# Altium schematic sheet mcu.SchDoc
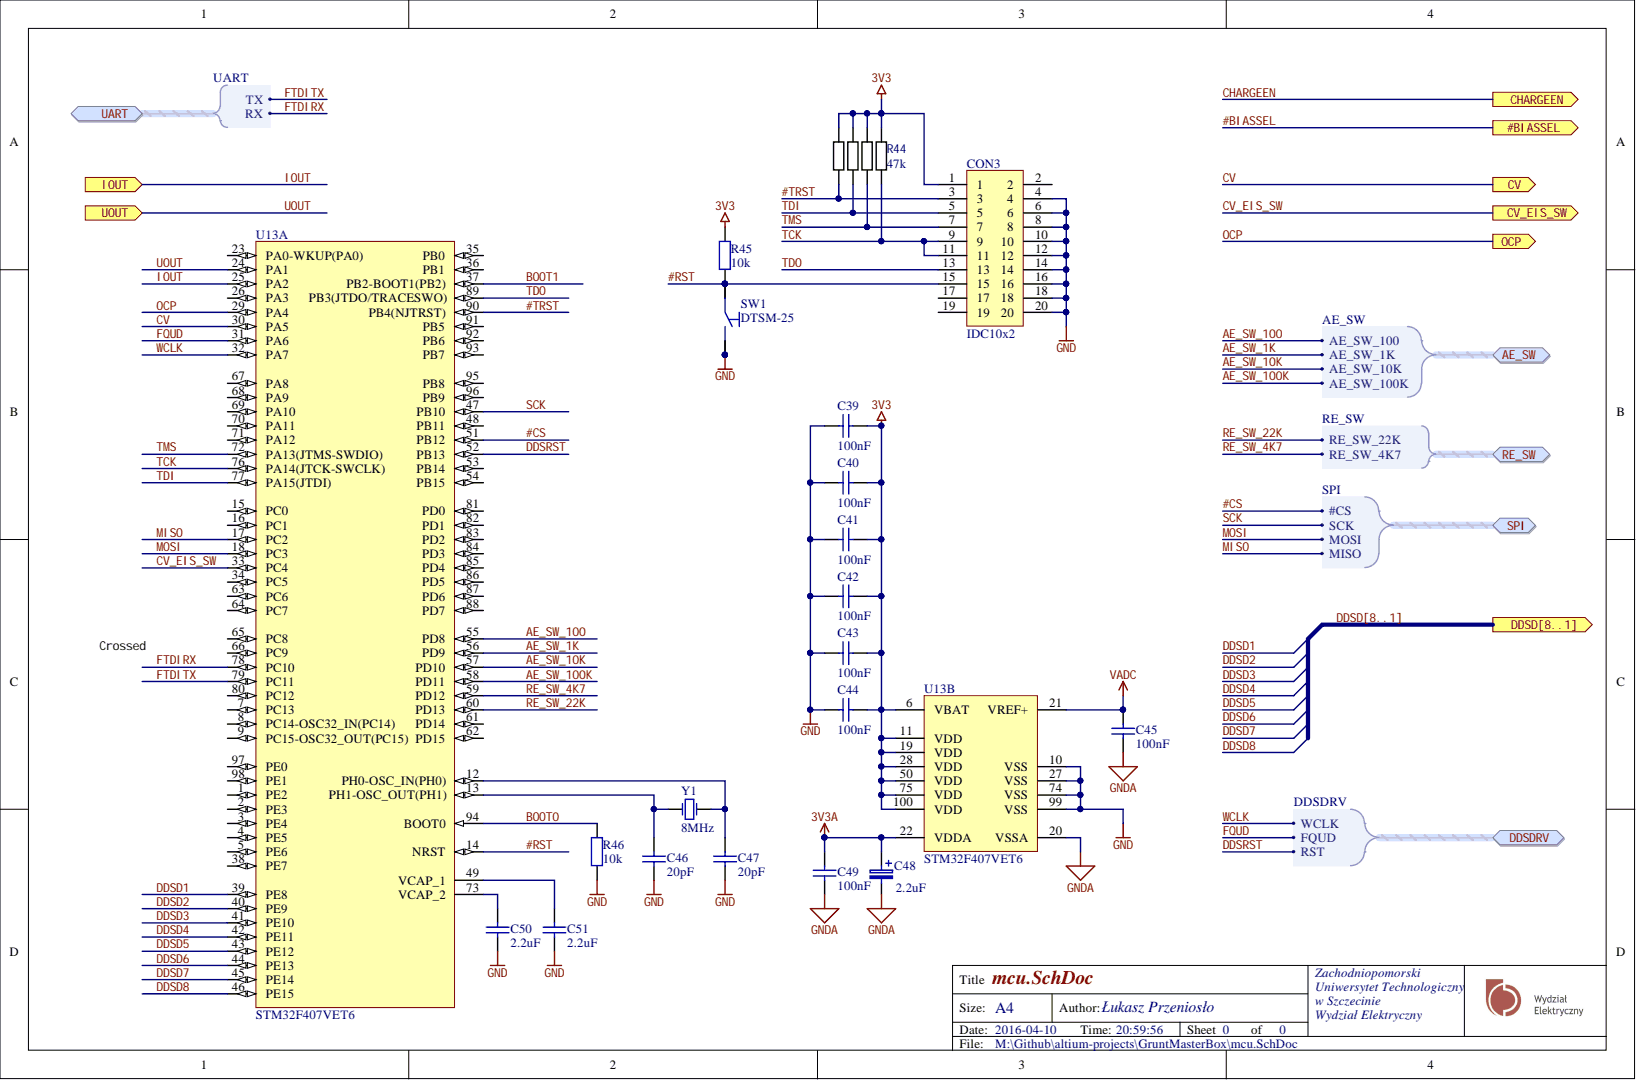
[diagram p0: mcu.SchDoc]

## Parts
C39  100nF  [CAPC1608N]
C40  100nF  [CAPC1608N]
C41  100nF  [CAPC1608N]
C42  100nF  [CAPC1608N]
C43  100nF  [CAPC1608N]
C44  100nF  [CAPC1608N]
C45  100nF  [CAPC1608N]
C46  20pF  [CAPC1608N]
C47  20pF  [CAPC1608N]
C48  2.2uF  [SMDA]
C49  100nF  [CAPC1608N]
C50  2.2uF  [CAPC1608N]
C51  2.2uF  [CAPC1608N]
CON3  IDC10x2  [IDC20_10x2]
R44  47k  [RES_ARRAY_4x0603]
R45  10k  [RESC1608N]
R46  10k  [RESC1608N]
SW1  DTSM-25  [DTSM-25]
U13  STM32F407VET6  [LQFP100_L]  (parts A,B)
Y1  8MHz  [HC49/4H_SMX]

## Ports
#BIASSEL, AE_SW, AE_SW.AE_SW_1K, AE_SW.AE_SW_10K, AE_SW.AE_SW_100, AE_SW.AE_SW_100K, CHARGEEN, CV, CV_EIS_SW, DDSD1, DDSD2, DDSD3, DDSD4, DDSD5, DDSD6, DDSD7, DDSD8, DDSD[8..1], DDSDRV, DDSDRV.FQUD, DDSDRV.RST, DDSDRV.WCLK, IOUT, OCP, RE_SW, RE_SW.RE_SW_4K7, RE_SW.RE_SW_22K, SPI, SPI.#CS, SPI.MISO, SPI.MOSI, SPI.SCK, UART, UART.RX, UART.TX, UOUT

## Nets
3V3: C39.2 C40.2 C41.2 C42.2 C43.2 C44.2 CON3.1 R44.2 R44.4 R44.6 R44.8 R45.2 U13.6(VBAT) U13.11(VDD) U13.19(VDD) U13.28(VDD) U13.50(VDD) U13.75(VDD) U13.100(VDD)
3V3A: C48.1 C49.2 U13.22(VDDA)
GND: C39.1 C40.1 C41.1 C42.1 C43.1 C44.1 C46.1 C47.1 C50.1 C51.1 CON3.4 CON3.6 CON3.8 CON3.10 CON3.12 CON3.14 CON3.16 CON3.18 CON3.20 R46.1 SW1.3 SW1.4 U13.10(VSS) U13.27(VSS) U13.74(VSS) U13.99(VSS)
GNDA: C45.1 C48.2 C49.1 U13.20(VSSA)
VADC: C45.2 U13.21(VREF+)
#CS: U13.51(PB12) ; port SPI
#RST: CON3.15 R45.1 SW1.1 SW1.2 U13.14(NRST)
#TRST: CON3.3 R44.7 U13.90(PB4(NJTRST))
AE_SW_1K: U13.56(PD9) ; port AE_SW
AE_SW_10K: U13.57(PD10) ; port AE_SW
AE_SW_100: U13.55(PD8) ; port AE_SW
AE_SW_100K: U13.58(PD11) ; port AE_SW
BOOT0: R46.2 U13.94(BOOT0)
BOOT1: U13.37(PB2-BOOT1(PB2))
CV: U13.30(PA5) ; port CV
CV_EIS_SW: U13.33(PC4) ; port CV_EIS_SW
DDSD1: U13.39(PE8) ; port DDSD[8..1]  [also labelled DDSD]
DDSD2: U13.40(PE9) ; port DDSD[8..1]  [also labelled DDSD]
DDSD3: U13.41(PE10) ; port DDSD[8..1]  [also labelled DDSD]
DDSD4: U13.42(PE11) ; port DDSD[8..1]  [also labelled DDSD]
DDSD5: U13.43(PE12) ; port DDSD[8..1]  [also labelled DDSD]
DDSD6: U13.44(PE13) ; port DDSD[8..1]  [also labelled DDSD]
DDSD7: U13.45(PE14) ; port DDSD[8..1]  [also labelled DDSD]
DDSD8: U13.46(PE15) ; port DDSD[8..1]  [also labelled DDSD]
DDSRST: U13.52(PB13) ; port DDSDRV
FQUD: U13.31(PA6) ; port DDSDRV
FTDIRX: U13.78(PC10) ; port UART
FTDITX: U13.79(PC11) ; port UART
IOUT: U13.25(PA2) ; port IOUT
MISO: U13.17(PC2) ; port SPI
MOSI: U13.18(PC3) ; port SPI
OCP: U13.29(PA4) ; port OCP
RE_SW_4K7: U13.59(PD12) ; port RE_SW
RE_SW_22K: U13.60(PD13) ; port RE_SW
SCK: U13.47(PB10) ; port SPI
TCK: CON3.9 CON3.11 R44.1 U13.76(PA14(JTCK-SWCLK))
TDI: CON3.5 R44.5 U13.77(PA15(JTDI))
TDO: CON3.13 U13.89(PB3(JTDO/TRACESWO))
TMS: CON3.7 R44.3 U13.72(PA13(JTMS-SWDIO))
UOUT: U13.24(PA1) ; port UOUT
WCLK: U13.32(PA7) ; port DDSDRV
NetC46_2: C46.2 U13.13(PH1-OSC_OUT(PH1)) Y1.1
NetC47_2: C47.2 U13.12(PH0-OSC_IN(PH0)) Y1.2
NetC50_2: C50.2 U13.73(VCAP_2)
NetC51_2: C51.2 U13.49(VCAP_1)
#BIASSEL: ; port #BIASSEL
CHARGEEN: ; port CHARGEEN

Not connected: CON3 2 17 19; U13 1(PE2) 2(PE3) 3(PE4) 4(PE5) 5(PE6) 7(PC13) 8(PC14-OSC32_IN(PC14)) 9(PC15-OSC32_OUT(PC15)) 15(PC0) 16(PC1) 23(PA0-WKUP(PA0)) 26(PA3) 34(PC5) 35(PB0) 36(PB1) 38(PE7) 48(PB11) 53(PB14) 54(PB15) 61(PD14) 62(PD15) 63(PC6) 64(PC7) 65(PC8) 66(PC9) 67(PA8) 68(PA9) 69(PA10) 70(PA11) 71(PA12) 80(PC12) 81(PD0) 82(PD1) 83(PD2) 84(PD3) 85(PD4) 86(PD5) 87(PD6) 88(PD7) 91(PB5) 92(PB6) 93(PB7) 95(PB8) 96(PB9) 97(PE0) 98(PE1)

## Library data of the parts
C39-C47 C49-C51 = Capacitor: Component Kind: Standard; Description: Capacitor; Library Name: Discrete.SchLib; Signal Integrity: Cap; Simulation: CAP
  C39-C45 C49: Value: 100nF
  C46 C47: Value: 20pF
  C50 C51: Value: 2.2uF
C48 = 100uF 6.3V-SMDB: Polarized Capacitor (Surface Mount); Value: 10uF; Component Kind: Standard; Distributor: tme.pl; Distributor Order Code: TC 100/6.3-SMDB; farnell: 1135048; PackageReference: SMDB; Signal Integrity: Cap Pol; Simulation: CAP; tme.pl: TC 100/6.3-SMDB; Library Name: Mechatronic.SchLib
CON3 = IDC20_10x2: Component Kind: Standard; Description: IDC10x2; Library Name: my_various_devices.SchLib
R44 = RES_ARRAY_1206: Component Kind: Standard; value: 47k; Library Name: my_various_devices.SchLib
R45 R46 = Resistor: Power: 0W; Tolerance: 5%; Component Kind: Standard; Description: Resistor; Library Name: Discrete.SchLib; Signal Integrity: Res; Simulation: RESISTOR; Value: 10k
U13 = STM32F407VET6: ARM Cortex-M4 32-bit MCU+FPU, 210 DMIPS, 512 kB Flash, 192 kB Internal RAM, 82 I/Os, 100-pin LQFP, -40 to 85 degC, Tray; Manufacturer: STMicroelectronics; D/A Converter: 2x12-bit; FLASH Size (Prog) (kB): 512; I/Os (High Current): 82; Internal RAM Size (kB): 192; Operating Frequency(F) (Processor speed) (MHz): 168; Supply Voltage(Vcc) max (V): 3.6; Supply Voltage(Vcc) min (V): 1.8; Component Kind: Standard; ComponentLink1Description: Manufacturer URL; ComponentLink1URL: http://www.st.com/web/en/home.html; ComponentLink2Description: Datasheet; ComponentLink2URL: http://www.st.com/st-web-ui/static/active/en/resource/technical/document/datasheet/DM00037051.pdf; ComponentLink3Description: Package Specification; ComponentLink3URL: http://www.st.com/st-web-ui/static/active/en/resource/technical/document/data_brief/DM00071938.pdf; Core: ARM Cortex-M4; DatasheetVersion: Rev. 4; Mounting Technology: Surface Mount; PackageDescription: 100-Pin Low Profile Quad Flat Package, 14 x 14 mm Body, 0.5 mm Pitch; PackageReference: LQFP100; PackageVersion: Rev. 1, 06/2013; Packing: Tray; PartId: 1; PartNumber: STM32F407VET6; Library Name: my_various_devices.SchLib
Y1 = HC49: Component Kind: Standard; Description: HC49; Value: 8MHz; Library Name: my_various_devices.SchLib
SW1 = DTSM-25: Component Kind: Standard; Description: DTSM-25; Library Name: my_various_devices.SchLib
